# Altium schematic sheet IR.SchDoc
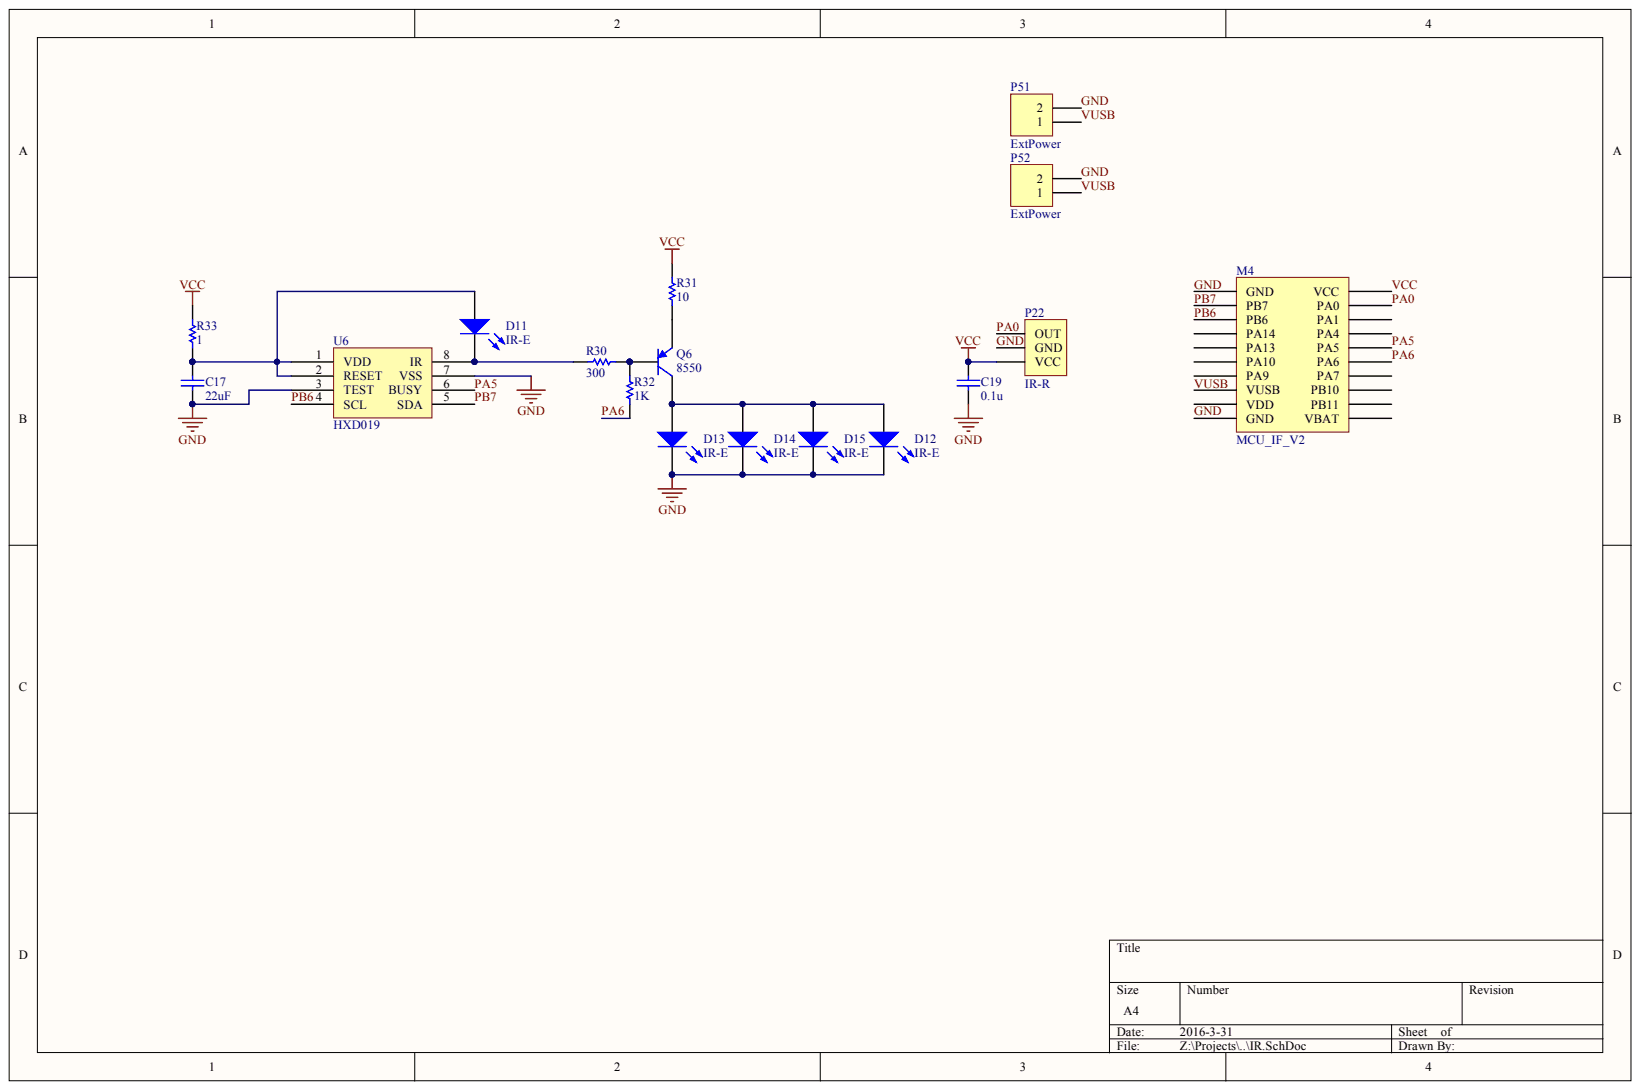
[diagram p0: IR.SchDoc]

## Parts
C17  value 22uF  (hidden comment: Cap Semi)
C19  value 0.1u  (hidden comment: Cap Semi)
D11  IR-E
D12  IR-E
D13  IR-E
D14  IR-E
D15  IR-E
M4  MCU_IF_V2
P22  IR-R
P51  ExtPower
P52  ExtPower
Q6  8550
R30  value 300  (hidden comment: Res Semi)
R31  value 10  (hidden comment: Res Semi)
R32  value 1K  (hidden comment: Res Semi)
R33  value 1  (hidden comment: Res Semi)
U6  HXD019

## Nets
GND: C17.2 C19.1 D12.2 D13.2 D14.2 D15.2 M4.1(GND) M4.10(GND) P22.2 P51.2 P52.2 U6.3(TEST) U6.7(VSS)
PA0: M4.19(PA0) P22.1
PA5: M4.16(PA5) U6.6(BUSY)
PA6: M4.15(PA6) R32.1
PB6: M4.3(PB6) U6.4(SCL)
PB7: M4.2(PB7) U6.5(SDA)
VCC: C19.2 M4.20(VCC) P22.3 R31.1 R33.1
VUSB: M4.8(VUSB) P51.1 P52.1
NetC17_1: C17.1 D11.1 R33.2 U6.1(VDD) U6.2(RESET)
NetD11_2: D11.2 R30.1 U6.8(IR)
NetD12_1: D12.1 D13.1 D14.1 D15.1 Q6.1
NetQ6_2: Q6.2 R30.2 R32.2
NetQ6_3: Q6.3 R31.2

Not connected: M4 4(PA14) 5(PA13) 6(PA10) 7(PA9) 9(VDD) 11(VBAT) 12(PB11) 13(PB10) 14(PA7) 17(PA4) 18(PA1)

## Library data of the parts
C17 C19 D11-D15 M4 P22 P51 P52 Q6 R30-R33 U6 = (no symbol name)
  C17: Published: 8-Jun-2000; LatestRevisionDate: 29-May-2009; LatestRevisionNote: IPC-7351 Footprint Added.; PackageReference: C1206; PackageDescription: Chip Capacitor
  C19: Published: 8-Jun-2000; LatestRevisionDate: 17-Jul-2002; LatestRevisionNote: Re-released for DXP Platform.; PackageReference: C1206
  D11-D15: Published: 8-Jun-2000; LatestRevisionDate: 08-Jul-2005; LatestRevisionNote: Mech Layer 1 removed.; PackageReference: LED-0
  P22 P51 P52: LatestRevisionDate: 17-Jul-2002; LatestRevisionNote: Re-released for DXP Platform.
  Q6: Published: 8-Jun-2000; LatestRevisionDate: 17-Jul-2002; LatestRevisionNote: Re-released for DXP Platform.; PackageReference: SOT-23B; Code_JEDEC: SOT-23
  R30-R33: Published: 8-Jun-2000; LatestRevisionDate: 17-Jul-2002; LatestRevisionNote: Re-released for DXP Platform.; PackageReference: AXIAL-0.5
  C17 C19 D11-D15 P22 P51 P52 Q6 R30-R33: Publisher: Altium Limited
